# Altium schematic sheet Microphone and Speaker.SchDoc
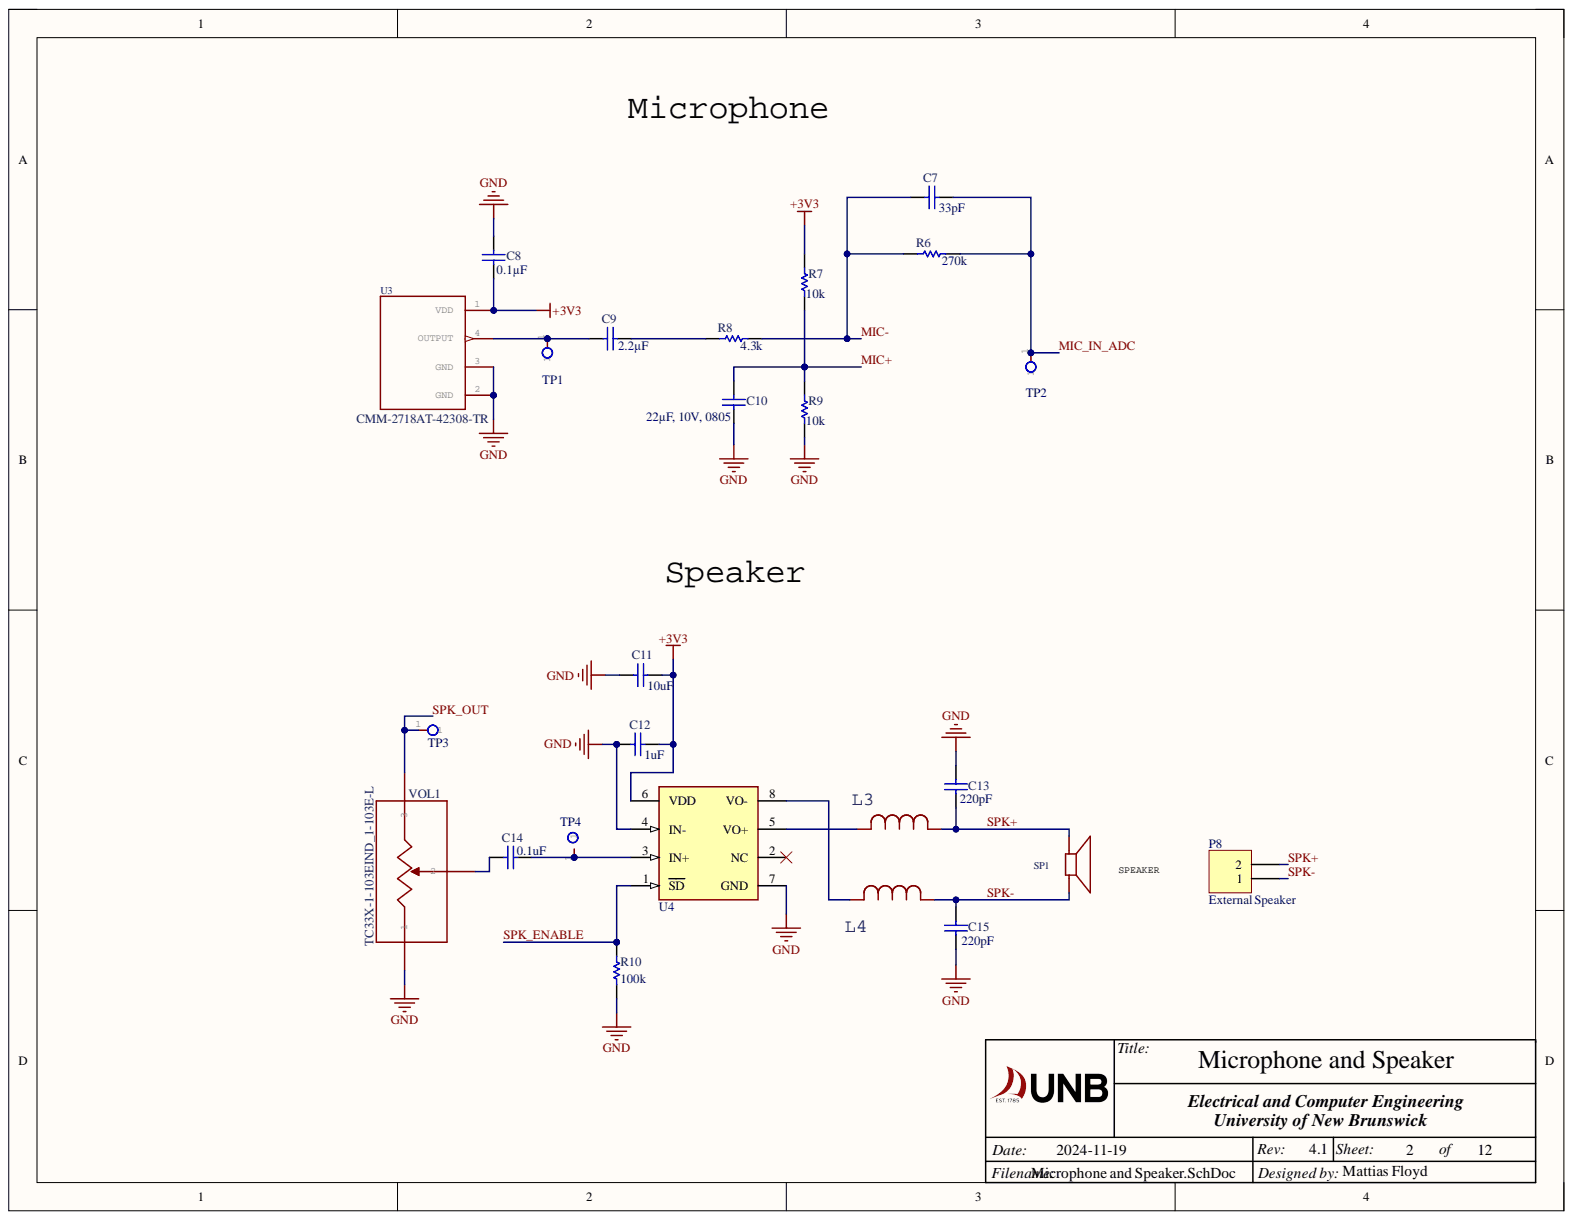
[diagram p0: Microphone and Speaker.SchDoc]

## Parts
C7  33pF  [0603 Cap]
C8  0.1µF  [0603 Cap]
C9  2.2µF  [0603 Cap]
C10  22µF, 10V, 0805  Case Code (Imperial): 0805  [0805 Cap]
C11  10uF  [0603 Cap]
C12  1uF  [0603 Cap]
C13  220pF  [0603 Cap]
C14  0.1uF  [0603 Cap]
C15  220pF  [0603 Cap]
L3  BLM18PG221SN1DIND_BLM18_0603_MUR-L  [IND_BLM18_0603_MUR-L]  (value not drawn)
L4  BLM18PG221SN1DIND_BLM18_0603_MUR-L  [IND_BLM18_0603_MUR-L]  (value not drawn)
P8  External Speaker  [HDR1X2]
R6  270k  [0603 Resistor]
R7  10k  [0603 Resistor]
R8  4.3k  [0603 Resistor]
R9  10k  [0603 Resistor]
R10  100k  [0603 Resistor]
SP1  SPEAKER  [AT-1127-ST-2-R]
TP1  Surface test point pad  [TEST_POINT]  (value not drawn)
TP2  Surface test point pad  [TEST_POINT]  (value not drawn)
TP3  Surface test point pad  [TEST_POINT]  (value not drawn)
TP4  Surface test point pad  [TEST_POINT]  (value not drawn)
U3  CMM-2718AT-42308-TR  [XDCR_CMM-2718AT-42308-TR]
U4  PAM8302AASCR  [FP-MSOP-8-IPC_A]  (value not drawn)
VOL1  TC33X-1-103EIND_1-103E-L  [TC33X-1]

## Nets
+3V3: C8.1 C11.2 C12.2 R7.2 U3.1(VDD) U4.6(VDD)
GND: C8.2 C10.1 C11.1 C12.1 C13.2 C15.1 R9.1 R10.2 U3.2(GND) U3.3(GND) U4.4(IN-) U4.7(GND) VOL1.1
MIC+: C10.2 R7.1 R9.2
MIC-: C7.1 R6.2 R8.1
MIC_IN_ADC: C7.2 R6.1 TP2.1
SPK+: C13.1 L3.1 P8.2 SP1.P$2
SPK-: C15.2 L4.1 P8.1 SP1.P$1
SPK_ENABLE: R10.1 U4.1(SD)
SPK_OUT: TP3.1 VOL1.3
NetC9_1: C9.1 TP1.1 U3.4(OUTPUT)
NetC9_2: C9.2 R8.2
NetC14_1: C14.1 VOL1.2
NetC14_2: C14.2 TP4.1 U4.3(IN+)
NetL3_2: L3.2 U4.5(VO+)
NetL4_2: L4.2 U4.8(VO-)

Not connected: U4 2(NC)

## Library data of the parts
C7 = Cap Semi: KEMET - C0603C330J1GACTU - SMD Multilayer Ceramic Capacitor, 33 pF, 100 V, 0603 [1608 Metric], &#177; 5%, C0G / NP0, C Series KEMET; Dissipation Factor: 0.1%; Temperature Coefficient: 30ppm/°C; Voltage Rating: 100V; Capacitance: 33pF; Case Code (Imperial): 0603; Case Code (Metric): 1608; Case/Package: 0603; Category: Ceramic Capacitors; Component Kind: Standard; ComponentLink1Description: http://www.avx.com/docs/Catalogs/cx7r.pdf; ComponentLink1URL: http://www.avx.com/docs/Catalogs/cx7r.pdf; ComponentLink2Description: https://datasheet.ciiva.com/13211/upy-gp-np0-16v-to-50v-8-13211019.pdf?src-supplier=Mouser; ComponentLink2URL: https://datasheet.ciiva.com/13211/upy-gp-np0-16v-to-50v-8-13211019.pdf?src-supplier=Mouser; ComponentLink3Description: https://datasheet.ciiva.com/7823/40889-7823734.pdf?src-supplier=Element14; ComponentLink3URL: https://datasheet.ciiva.com/7823/40889-7823734.pdf?src-supplier=Element14; ComponentLink4Description: https://datasheet.ciiva.com/5420/kem-c1003-c0g-smd-356956-5420521.pdf?src-supplier=Mouser; ComponentLink4URL: https://datasheet.ciiva.com/5420/kem-c1003-c0g-smd-356956-5420521.pdf?src-supplier=Mouser; ComponentLink5Description: https://datasheet.ciiva.com/22705/40889-22705434.pdf?src-supplier=Element14; ComponentLink5URL: https://datasheet.ciiva.com/22705/40889-22705434.pdf?src-supplier=Element14; ComponentLink6Description: https://datasheet.ciiva.com/28668/1789732-28668653.pdf?src-supplier=Element14; ComponentLink6URL: https://datasheet.ciiva.com/28668/1789732-28668653.pdf?src-supplier=Element14; Depth: 0.8mm; Dielectric: C0G; Dielectric Material: Ceramic; Height: 0.8mm; Lead Free: Lead Free; Lead Pitch: 0.7mm; Length: 1.6mm; Library Name: CAN_Interface_Library.SCHLIB; Manufacturer: KEMET; Manufacturer Part Number: C0603C330J1GACTU; Max Operating Temperature: 125°C; Min Operating Temperature: -55°C; Mount: Surface Mount; Number of Pins: 2; Package Quantity: 1; Packaging: Tape and Reel; REACH SVHC: No SVHC; RoHS: Yes; Signal Integrity: Cap Semi; Simulation: CAP; Stock 1: 150603; Supplier 1: Digi-Key; Supplier Part Number 1: 399-7896-1-ND; Termination: SMD/SMT; Thickness: 0.034inch; Tolerance: 5%; Value: 33pF; Voltage Rating (DC): 100V; Width: 0.031inch
C8 C14 = Cap Semi: Cap Ceramic 0.1uF 50VDC X7R 10% SMD 0603 Paper T/R; Manufacturer: Samsung; Manufacturer Part Number: CL10B104KB8NNNC; Capacitance: 100nF; Voltage: 50V; Case Code (Imperial): 0603; Case Code (Metric): 1608; Case/Package: 0603; Category: Ceramic Capacitors; Component Kind: Standard; ComponentLink1Description: http://www.avx.com/docs/Catalogs/cx7r.pdf; ComponentLink1URL: http://www.avx.com/docs/Catalogs/cx7r.pdf; ComponentLink2Description: https://datasheet.ciiva.com/25803/cl10b104kb8nnnc-25803393.pdf?src-supplier=Digi-Key; ComponentLink2URL: https://datasheet.ciiva.com/25803/cl10b104kb8nnnc-25803393.pdf?src-supplier=Digi-Key; ComponentLink3Description: https://datasheet.ciiva.com/26838/getdatasheetpartid-89097-26838411.pdf?src-supplier=Verical; ComponentLink3URL: https://datasheet.ciiva.com/26838/getdatasheetpartid-89097-26838411.pdf?src-supplier=Verical; ComponentLink4Description: https://datasheet.ciiva.com/29198/456651-da-01-en-vs-kondensator-0605-29198839.pdf?src-supplier=Conrad; ComponentLink4URL: https://datasheet.ciiva.com/29198/456651-da-01-en-vs-kondensator-0605-29198839.pdf?src-supplier=Conrad; Contact Plating: Tin; Depth: 0.8mm; Dielectric: X7R; Height: 0.8mm; Lead Free: Lead Free; Length: 1.6mm; Library Name: CAN_Interface_Library.SCHLIB; Material: Ceramic; Max Operating Temperature: 125°C; Min Operating Temperature: -55°C; Mount: Surface Mount; Package Quantity: 1; Packaging: Tape and Reel; Radiation Hardening: No; RoHS: Yes; Signal Integrity: Cap Semi; Simulation: CAP; Stock 1: 17879275; Supplier 1: Digi-Key; Supplier Part Number 1: 1276-1000-6-ND; Thickness: 0.035inch; Tolerance: 10%; Voltage Rating: 50V; Voltage Rating (DC): 50V; Width: 0.031inch
  C8: Value: 0.1µF
  C14: Value: 0.1uF
C9 = Cap Semi: SAMSUNG ELECTRO-MECHANICS - CL10A225KP8NNNC - SMD Multilayer Ceramic Capacitor, 2.2 µF, 10 V, 0603 [1608 Metric], ± 10%, X5R, CL Series; Capacitance: 2.2uF; Voltage: 10V; Case Code (Imperial): 0603; Case Code (Metric): 1608; Case/Package: 0603; Category: Ceramic Capacitors; Component Kind: Standard; ComponentLink1Description: http://www.avx.com/docs/Catalogs/cx7r.pdf; ComponentLink1URL: http://www.avx.com/docs/Catalogs/cx7r.pdf; ComponentLink2Description: https://datasheet.ciiva.com/25799/cl10a225kp8nnnc-25799697.pdf?src-supplier=Digi-Key; ComponentLink2URL: https://datasheet.ciiva.com/25799/cl10a225kp8nnnc-25799697.pdf?src-supplier=Digi-Key; ComponentLink3Description: https://datasheet.ciiva.com/26838/getdatasheetpartid-358617-26838359.pdf?src-supplier=Verical; ComponentLink3URL: https://datasheet.ciiva.com/26838/getdatasheetpartid-358617-26838359.pdf?src-supplier=Verical; ComponentLink4Description: https://datasheet.ciiva.com/29198/457945-da-01-en-vs-kondensator-0603-29198592.pdf?src-supplier=Conrad; ComponentLink4URL: https://datasheet.ciiva.com/29198/457945-da-01-en-vs-kondensator-0603-29198592.pdf?src-supplier=Conrad; Depth: 0.8mm; Dielectric: X5R; Height: 0.8mm; Lead Free: Lead Free; Length: 1.6mm; Library Name: CAN_Interface_Library.SCHLIB; Manufacturer: Samsung; Manufacturer Part Number: CL10A225KP8NNNC; Material: Ceramic; Max Operating Temperature: 85°C; Min Operating Temperature: -55°C; Mount: Surface Mount; Package Quantity: 1; Packaging: Tape and Reel; Radiation Hardening: No; RoHS: Yes; Signal Integrity: Cap Semi; Simulation: CAP; Stock 1: 1391778; Supplier 1: Digi-Key; Supplier Part Number 1: 1276-1085-1-ND; Thickness: 0.035inch; Tolerance: 10%; Value: 2.2µF; Voltage Rating: 10V; Voltage Rating (DC): 10V; Width: 0.031inch
C10 = Cap Semi: SAMSUNG ELECTRO-MECHANICS - CL21A106KOQNNNE - SMD Multilayer Ceramic Capacitor, 10 µF, 16 V, 0805 [2012 Metric], ± 10%, X5R, CL Series; Capacitance: 10uF; Dielectric: X7R; Voltage: 16V; Case Code (Metric): 2012; Case/Package: 0805; Category: Ceramic Capacitors; Component Kind: Standard; ComponentLink1Description: http://www.avx.com/docs/Catalogs/cx7r.pdf; ComponentLink1URL: http://www.avx.com/docs/Catalogs/cx7r.pdf; ComponentLink2Description: https://datasheet.ciiva.com/15216/getdatasheetpartid-358435-15216234.pdf?src-supplier=Verical; ComponentLink2URL: https://datasheet.ciiva.com/15216/getdatasheetpartid-358435-15216234.pdf?src-supplier=Verical; ComponentLink3Description: https://datasheet.ciiva.com/25798/cl21a106koqnnne-25798133.pdf?src-supplier=Digi-Key; ComponentLink3URL: https://datasheet.ciiva.com/25798/cl21a106koqnnne-25798133.pdf?src-supplier=Digi-Key; ComponentLink4Description: https://datasheet.ciiva.com/16740/cl05b104kq5nnnc-16740697.pdf?src-supplier=Future+Electronics; ComponentLink4URL: https://datasheet.ciiva.com/16740/cl05b104kq5nnnc-16740697.pdf?src-supplier=Future+Electronics; Depth: 1.25mm; Height: 1.25mm; Lead Free: Lead Free; Length: 2mm; Library Name: CAN_Interface_Library.SCHLIB; Manufacturer: Samsung; Manufacturer Part Number: CL21A106KOQNNNE; Max Operating Temperature: 85°C; Min Operating Temperature: -55°C; Mount: Surface Mount; Package Quantity: 1; Packaging: Tape and Reel; Radiation Hardening: No; RoHS: Yes; Signal Integrity: Cap Semi; Simulation: CAP; Stock 1: 963001; Supplier 1: Digi-Key; Supplier Part Number 1: 1276-1096-1-ND; Thickness: 0.055inch; Tolerance: 10%; Value: 22µF, 10V, 0805; Voltage Rating: 16V; Voltage Rating (DC): 16V; Width: 0.049inch
C11 = Cap Semi: Cap Ceramic 10uF 6.3V X5R 20% Pad SMD 0603 85°C T/R; Manufacturer: Samsung; Manufacturer Part Number: CL10A106MQ8NNNC; Capacitance: 10uF; Case Code (Imperial): 0603; Case Code (Metric): 1608; Case/Package: 0603; Category: Ceramic Capacitors; Component Kind: Standard; ComponentLink1Description: http://www.avx.com/docs/Catalogs/cx7r.pdf; ComponentLink1URL: http://www.avx.com/docs/Catalogs/cx7r.pdf; ComponentLink2Description: https://datasheet.ciiva.com/25798/cl10a106mq8nnnc-25798320.pdf?src-supplier=Digi-Key; ComponentLink2URL: https://datasheet.ciiva.com/25798/cl10a106mq8nnnc-25798320.pdf?src-supplier=Digi-Key; ComponentLink3Description: https://datasheet.ciiva.com/26838/getdatasheetpartid-357557-26838347.pdf?src-supplier=Verical; ComponentLink3URL: https://datasheet.ciiva.com/26838/getdatasheetpartid-357557-26838347.pdf?src-supplier=Verical; ComponentLink4Description: https://datasheet.ciiva.com/16437/cl05b104kq5nnnc-16437327.pdf?src-supplier=Future+Electronics; ComponentLink4URL: https://datasheet.ciiva.com/16437/cl05b104kq5nnnc-16437327.pdf?src-supplier=Future+Electronics; Contact Plating: Tin; Depth: 0.8mm; Dielectric: X5R; Height: 0.8mm; Length: 1.6mm; Library Name: CAN_Interface_Library.SCHLIB; Max Operating Temperature: 85°C; Min Operating Temperature: -55°C; Mount: Surface Mount; Package Quantity: 1; Packaging: Tape and Reel; Radiation Hardening: No; RoHS: Yes; Signal Integrity: Cap Semi; Simulation: CAP; Stock 1: 1321; Supplier 1: Digi-Key; Supplier Part Number 1: 1276-1119-1-ND; Thickness: 0.035inch; Tolerance: 20%; Value: 10uF; Voltage: 6.3V; Voltage Rating: 6.3V; Width: 0.031inch
C12 = Cap Semi: CAP CER 1UF 10V X7R 0603; Manufacturer: Samsung; Manufacturer Part Number: CL10B105KP8NFNC; Tolerance: 10%; Capacitance: 1uF; Case Code (Imperial): 0603; Case Code (Metric): 1608; Case/Package: 0603; Category: Ceramic Capacitors; Component Kind: Standard; ComponentLink1Description: http://www.avx.com/docs/Catalogs/cx7r.pdf; ComponentLink1URL: http://www.avx.com/docs/Catalogs/cx7r.pdf; ComponentLink2Description: https://datasheet.ciiva.com/25737/cl10b105kp8nfnc-25737841.pdf?src-supplier=Digi-Key; ComponentLink2URL: https://datasheet.ciiva.com/25737/cl10b105kp8nfnc-25737841.pdf?src-supplier=Digi-Key; Depth: 0.8mm; Dielectric: X7R; Height: 0.8mm; Length: 0.063inch; Library Name: CAN_Interface_Library.SCHLIB; Max Operating Temperature: 125°C; Min Operating Temperature: -55°C; Mount: Surface Mount; Package Quantity: 1; Packaging: Tape and Reel; Radiation Hardening: No; RoHS: Yes; Signal Integrity: Cap Semi; Simulation: CAP; Stock 1: 117122; Supplier 1: Digi-Key; Supplier Part Number 1: 1276-1945-1-ND; Thickness: 0.035inch; Value: 1uF; Voltage Rating: 10V; Width: 0.031inch
C13 C15 = Cap Semi: CL Series 0603 220 pF 50 V ±10% X7R Surface Mount Multilayer Ceramic Capacitor; Manufacturer: Samsung; Manufacturer Part Number: CL10B221KB8NFNC; Voltage Rating: 50V; Capacitance: 220pF; Case Code (Imperial): 0603; Case Code (Metric): 1608; Case/Package: 0603; Category: Ceramic Capacitors; Component Kind: Standard; ComponentLink1Description: http://www.avx.com/docs/Catalogs/cx7r.pdf; ComponentLink1URL: http://www.avx.com/docs/Catalogs/cx7r.pdf; ComponentLink2Description: https://datasheet.ciiva.com/25739/cl10b221kb8nfnc-25739329.pdf?src-supplier=Digi-Key; ComponentLink2URL: https://datasheet.ciiva.com/25739/cl10b221kb8nfnc-25739329.pdf?src-supplier=Digi-Key; ComponentLink3Description: https://datasheet.ciiva.com/16428/cl10b221kb8nfnc-16428861.pdf?src-supplier=Future+Electronics; ComponentLink3URL: https://datasheet.ciiva.com/16428/cl10b221kb8nfnc-16428861.pdf?src-supplier=Future+Electronics; Dielectric: X7R; Length: 0.063inch; Library Name: CAN_Interface_Library.SCHLIB; Max Operating Temperature: 125°C; Min Operating Temperature: -55°C; Mount: Surface Mount; Package Quantity: 1; Packaging: Tape and Reel; RoHS: Yes; Signal Integrity: Cap Semi; Simulation: CAP; Stock 1: 58768; Supplier 1: Digi-Key; Supplier Part Number 1: 1276-1986-1-ND; Thickness: 0.035inch; Tolerance: 10%; Value: 220pF; Width: 0.031inch
L3 L4 = BLM18PG221SN1DIND_BLM18_0603_MUR-L: Ferrite Beads Multi-Layer 220Ohm 25% 100MHz 1.4A 100mOhm DCR 0603 T/R; Manufacturer: Murata; DC Resistance (DCR): 100mR; ESR (Equivalent Series Resistance): 220R; Material: Steel,Ferrite; Number of Circuits: 1; Shielding: Unshielded; Case Code (Imperial): 0603; Case Code (Metric): 1608; Case/Package: 0603; Category: Ferrite Beads and Chips; Component Kind: Standard; ComponentLink1Description: https://datasheet.ciiva.com/19458/1845030-19458417.pdf?src-supplier=Jameco; ComponentLink1URL: https://datasheet.ciiva.com/19458/1845030-19458417.pdf?src-supplier=Jameco; ComponentLink2Description: https://datasheet.ciiva.com/11800/0900766b81275ca5-11800851.pdf?src-supplier=RSComponents; ComponentLink2URL: https://datasheet.ciiva.com/11800/0900766b81275ca5-11800851.pdf?src-supplier=RSComponents; ComponentLink3Description: https://datasheet.ciiva.com/29347/442391-da-01-en-chip-drossel-0603-220r-1400ma-1-6x0-8mm-29347986.pdf?src-supplier=Conrad; ComponentLink3URL: https://datasheet.ciiva.com/29347/442391-da-01-en-chip-drossel-0603-220r-1400ma-1-6x0-8mm-29347986.pdf?src-supplier=Conrad; ComponentLink4Description: https://datasheet.ciiva.com/1012/l0110s0100blm18p-1012161.pdf?src-supplier=Digi-Key; ComponentLink4URL: https://datasheet.ciiva.com/1012/l0110s0100blm18p-1012161.pdf?src-supplier=Digi-Key; ComponentLink5Description: https://datasheet.ciiva.com/3081/l0110s0100blm18p-3081633.pdf?src-supplier=Avnet; ComponentLink5URL: https://datasheet.ciiva.com/3081/l0110s0100blm18p-3081633.pdf?src-supplier=Avnet; ComponentLink6Description: https://datasheet.ciiva.com/1012/l0110s0100blm18p-1012162.pdf?src-supplier=Digi-Key; ComponentLink6URL: https://datasheet.ciiva.com/1012/l0110s0100blm18p-1012162.pdf?src-supplier=Digi-Key; ComponentLink7Description: https://datasheet.ciiva.com/1012/l0110s0100blm18p-1012163.pdf?src-supplier=Digi-Key; ComponentLink7URL: https://datasheet.ciiva.com/1012/l0110s0100blm18p-1012163.pdf?src-supplier=Digi-Key; ComponentLink8Description: https://datasheet.ciiva.com/8021/5148-8021479.pdf?src-supplier=Element14; ComponentLink8URL: https://datasheet.ciiva.com/8021/5148-8021479.pdf?src-supplier=Element14; ComponentLink9Description: https://datasheet.ciiva.com/14122/blm-series-eng-tds-14122909.pdf?src-supplier=Distrelec; ComponentLink9URL: https://datasheet.ciiva.com/14122/blm-series-eng-tds-14122909.pdf?src-supplier=Distrelec; ComponentLink10Description: https://datasheet.ciiva.com/16205/l0110s0100blm18p-16205422.pdf?src-supplier=Future+Electronics; ComponentLink10URL: https://datasheet.ciiva.com/16205/l0110s0100blm18p-16205422.pdf?src-supplier=Future+Electronics; ComponentLink11Description: https://datasheet.ciiva.com/8021/5148-8021482.pdf?src-supplier=Element14; ComponentLink11URL: https://datasheet.ciiva.com/8021/5148-8021482.pdf?src-supplier=Element14; Core Material: Ferrite; Current Rating: 1.4A; DC Current: 1.4A; Depth: 0.8mm; Height: 0.8mm; Impedance: 220R; Lead Free: Lead Free; Length: 1.6mm; Manufacturer Part Number: BLM18PG221SN1D; Max Current Rating: 1.4A; Max DC Current: 1.4A; Max Operating Temperature: 125°C; Min Operating Temperature: -55°C; Number of Lines: 1; Number of Pins: 2; Package Quantity: 1; Packaging: Tape and Reel; Radiation Hardening: No; REACH SVHC: No SVHC; Resistance: 100mR; RoHS: Yes; Stock 1: 141533; Supplier 1: Digi-Key; Supplier Part Number 1: 490-5221-1-ND; Termination: SMD/SMT; Test Frequency: 100MHz; Tolerance: 25%; Weight: 7.1E-05oz; Width: 0.8mm; Library Name: BrilliantLabsEagleLibrary.IntLib
P8 = Header 2: Header, 2-Pin; Component Kind: Standard; LatestRevisionDate: 17-Jul-2002; LatestRevisionNote: Re-released for DXP Platform.; Library Name: Miscellaneous Connectors.IntLib; Signal Integrity: Connector; Publisher: Altium Limited
R6 = Res Semi: Res Thick Film 0603 270K Ohm 1% 0.1W(1/10W) ±100ppm/C Pad SMD Automotive T/R; Manufacturer: Stackpole Electronics; Manufacturer Part Number: RMCF0603FT270K; Max Power Dissipation: 100mW; Resistance: 270kR; Temperature Coefficient: 100ppm/°C; Case Code (Imperial): 0603; Case Code (Metric): 1608; Case/Package: 0603; Category: Chip SMD Resistors; Component Kind: Standard; ComponentLink1Description: http://www.rohm.com/web/global/datasheet/ESR01MZPF/esr-e; ComponentLink1URL: http://www.rohm.com/web/global/datasheet/ESR01MZPF/esr-e; ComponentLink2Description: http://components.arrow.com/part/dataview/50861785S7394934N1174?src-supplier=Arrow+Electronics; ComponentLink2URL: http://components.arrow.com/part/dataview/50861785S7394934N1174?src-supplier=Arrow+Electronics; ComponentLink3Description: https://www.seielect.com/catalog/SEI-RMCF_RMCP.pdf?src-supplier=Avnet; ComponentLink3URL: https://www.seielect.com/catalog/SEI-RMCF_RMCP.pdf?src-supplier=Avnet; ComponentLink4Description: https://www.seielect.com/Catalog/SEI-RMCF_RMCP.pdf?src-supplier=Digi-Key; ComponentLink4URL: https://www.seielect.com/Catalog/SEI-RMCF_RMCP.pdf?src-supplier=Digi-Key; ComponentLink5Description: https://www.seielect.com/catalog/SEI-Packaging.pdf?src-supplier=Digi-Key; ComponentLink5URL: https://www.seielect.com/catalog/SEI-Packaging.pdf?src-supplier=Digi-Key; Composition: Thick Film; Contact Plating: Tin,Matte; Depth: 0.8mm; Features: Automotive AEC-Q200; Height: 0.022inch; Lead Free: Lead Free; Length: 0.061inch; Max Operating Temperature: 155°C; Military Standard: Not; Min Operating Temperature: -55°C; Mount: Surface Mount; Number of Terminations: 2; Packaging: Tape and Reel; Radiation Hardening: No; RoHS: Yes; Signal Integrity: Res Semi; Simulation: RES; Stock 1: 61185; Supplier 1: Digi-Key; Supplier Part Number 1: RMCF0603FT270KCT-ND; Tolerance: 1%; Value: 270k; Width: 0.031inch; Library Name: BrillianBit.SCHLIB
R7 R9 = Res Semi: Res Thick Film 0603 10K Ohm 1% 0.1W(1/10W) ±100ppm/C Molded SMD Automotive T/R; Manufacturer: Stackpole Electronics; Manufacturer Part Number: RMCF0603FT10K0; Max Power Dissipation: 100mW; Resistance: 10kR; Temperature Coefficient: 100ppm/°C; Case Code (Imperial): 0603; Case Code (Metric): 1608; Case/Package: 0603; Category: Chip SMD Resistors; Component Kind: Standard; ComponentLink1Description: http://www.rohm.com/web/global/datasheet/ESR01MZPF/esr-e; ComponentLink1URL: http://www.rohm.com/web/global/datasheet/ESR01MZPF/esr-e; ComponentLink2Description: http://components.arrow.com/part/dataview/43747082S6756917N1174?src-supplier=Arrow+Electronics; ComponentLink2URL: http://components.arrow.com/part/dataview/43747082S6756917N1174?src-supplier=Arrow+Electronics; ComponentLink3Description: https://www.seielect.com/catalog/sei-rmcf_rmcp.pdf?src-supplier=Digi-Key; ComponentLink3URL: https://www.seielect.com/catalog/sei-rmcf_rmcp.pdf?src-supplier=Digi-Key; ComponentLink4Description: https://www.seielect.com/catalog/SEI-RMCF_RMCP.pdf?src-supplier=Avnet; ComponentLink4URL: https://www.seielect.com/catalog/SEI-RMCF_RMCP.pdf?src-supplier=Avnet; ComponentLink5Description: http://www.seielect.com/catalog/sei-rmc.pdf?src-supplier=Future+Electronics; ComponentLink5URL: http://www.seielect.com/catalog/sei-rmc.pdf?src-supplier=Future+Electronics; ComponentLink6Description: https://www.verical.com/server-webapp/html/getDatasheet?partID=360913&src-supplier=Verical; ComponentLink6URL: https://www.verical.com/server-webapp/html/getDatasheet?partID=360913&src-supplier=Verical; Composition: Thick Film; Contact Plating: Tin,Matte; Depth: 0.8mm; Features: Automotive AEC-Q200; Height: 0.022inch; Lead Free: Lead Free; Length: 1.55mm; Max Operating Temperature: 155°C; Military Standard: Not; Min Operating Temperature: -55°C; Mount: Surface Mount; Number of Terminations: 2; Packaging: Tape and Reel; Power Rating: 100mW; Radiation Hardening: No; RoHS: Yes; Signal Integrity: Res Semi; Simulation: RES; Stock 1: 1608506; Supplier 1: Digi-Key; Supplier Part Number 1: RMCF0603FT10K0CT-ND; Tolerance: 1%; Value: 10k; Width: 0.031inch; Library Name: BrillianBit.SCHLIB
R8 = Res Semi: RES SMD 4.3K OHM 1% 1/10W 0603; Manufacturer: Stackpole Electronics; Manufacturer Part Number: RMCF0603FT4K30; Max Power Dissipation: 100mW; Resistance: 4.3kR; Temperature Coefficient: 100ppm/°C; Case Code (Imperial): 0603; Case Code (Metric): 1608; Case/Package: 0603; Category: Chip SMD Resistors; Component Kind: Standard; ComponentLink1Description: http://www.rohm.com/web/global/datasheet/ESR01MZPF/esr-e; ComponentLink1URL: http://www.rohm.com/web/global/datasheet/ESR01MZPF/esr-e; ComponentLink2Description: http://components.arrow.com/part/dataview/50496135S184625N1174?src-supplier=Arrow+Electronics; ComponentLink2URL: http://components.arrow.com/part/dataview/50496135S184625N1174?src-supplier=Arrow+Electronics; ComponentLink3Description: https://www.seielect.com/catalog/SEI-RMCF_RMCP.pdf?src-supplier=Avnet; ComponentLink3URL: https://www.seielect.com/catalog/SEI-RMCF_RMCP.pdf?src-supplier=Avnet; ComponentLink4Description: https://www.seielect.com/Catalog/SEI-RMCF_RMCP.pdf?src-supplier=Digi-Key; ComponentLink4URL: https://www.seielect.com/Catalog/SEI-RMCF_RMCP.pdf?src-supplier=Digi-Key; ComponentLink5Description: https://www.seielect.com/catalog/SEI-Packaging.pdf?src-supplier=Digi-Key; ComponentLink5URL: https://www.seielect.com/catalog/SEI-Packaging.pdf?src-supplier=Digi-Key; Composition: Thick Film; Contact Plating: Tin,Matte; Features: Automotive AEC-Q200; Height: 0.022inch; Lead Free: Lead Free; Length: 0.061inch; Max Operating Temperature: 155°C; Min Operating Temperature: -55°C; Mount: Surface Mount; Number of Terminations: 2; Packaging: Tape and Reel; Radiation Hardening: No; RoHS: Yes; Signal Integrity: Res Semi; Simulation: RES; Stock 1: 36145; Supplier 1: Digi-Key; Supplier Part Number 1: RMCF0603FT4K30CT-ND; Tolerance: 1%; Value: 4.3k; Width: 0.031inch; Library Name: BrillianBit.SCHLIB
R10 = Res Semi: RES 100K OHM 1% 1/10W 0603; Manufacturer: Stackpole Electronics; Manufacturer Part Number: RMCF0603FT100K; Max Power Dissipation: 100mW; Resistance: 100kR; Temperature Coefficient: 100ppm/°C; Working Voltage: 75V; Case Code (Imperial): 0603; Case Code (Metric): 1608; Case/Package: 0603; Category: Chip SMD Resistors; Component Kind: Standard; ComponentLink1Description: http://www.rohm.com/web/global/datasheet/ESR01MZPF/esr-e; ComponentLink1URL: http://www.rohm.com/web/global/datasheet/ESR01MZPF/esr-e; ComponentLink2Description: https://datasheet.ciiva.com/15193/getdatasheetpartid-362597-15193437.pdf?src-supplier=Verical; ComponentLink2URL: https://datasheet.ciiva.com/15193/getdatasheetpartid-362597-15193437.pdf?src-supplier=Verical; Composition: Thick Film; Contact Plating: Tin,Matte; Depth: 0.8mm; Features: Automotive AEC-Q200; Height: 0.022inch; Lead Free: Lead Free; Length: 1.55mm; Max Operating Temperature: 155°C; Military Standard: Not; Min Operating Temperature: -55°C; Mount: Surface Mount; Number of Terminations: 2; Packaging: Tape and Reel; Power Rating: 100mW; Radiation Hardening: No; RoHS: Yes; Signal Integrity: Res Semi; Simulation: RES; Stock 1: 2666095; Supplier 1: Digi-Key; Supplier Part Number 1: RMCF0603FT100KDKR-ND; Tolerance: 1%; Value: 100k; Width: 0.031inch; Library Name: BrillianBit.SCHLIB
SP1 = SPEAKER: AUDIO MAGNETIC XDCR 2-4V TH; Manufacturer: Projects Unlimited; Frequency: 2.73kHz; Operating Supply Current: 80mA; Voltage Rating: 3V; Category: Audio Products; Component Kind: Standard; ComponentLink1Description: http://www.mouser.com/ds/2/334/AT-1127-ST-2-R-532246.pdf?src-supplier=Mouser; ComponentLink1URL: http://www.mouser.com/ds/2/334/AT-1127-ST-2-R-532246.pdf?src-supplier=Mouser; ComponentLink2Description: http://www.puiaudio.com/pdf/AT-1127-ST-2-R.pdf?src-supplier=Digi-Key; ComponentLink2URL: http://www.puiaudio.com/pdf/AT-1127-ST-2-R.pdf?src-supplier=Digi-Key; ComponentLink3Description: http://www.puiaudio.com/pdf/AT-1127-ST-2-R.pdf?src-supplier=Avnet; ComponentLink3URL: http://www.puiaudio.com/pdf/AT-1127-ST-2-R.pdf?src-supplier=Avnet; Contact Plating: Gold; Diameter: 9mm; Height: 4.5mm; Manufacturer Part Number: AT-1127-ST-2-R; Max Operating Temperature: 70°C; Min Operating Temperature: -20°C; Mount: Through Hole; Packaging: Tray; RoHS: Yes; Sensing Method: Magnetic; Stock 1: 14405; Supplier 1: Digi-Key; Supplier Part Number 1: 668-1463-ND; Termination: PC Pins; Library Name: BrilliantLabsEagleLibrary.IntLib
TP1-TP4 = TESTPOINT: GateName_1: A; SymbolName_1: TP; Component Kind: Standard; DeviceSetName: TESTPOINT; Package: TEST_POINT; Library Name: bBoard for UNB Schematic Library.SCHLIB; LibraryName: BrilliantLabsEagleLibrary
U3 = CMM-2718AT-42308-TR: 2.75 x 1.85 mm, Analog, Top Port, Surface Mount, 2.0 Vdc, -42 ±3 dB, MEMS Microphone; Manufacturer: CUI Devices; Component Kind: Standard; ComponentLink1Description: http://datasheet.octopart.com/CMM-2718AT-42308-TR-CUI-Devices-datasheet-142081213.pdf?src-supplier=Electro+Sonic; ComponentLink1URL: http://datasheet.octopart.com/CMM-2718AT-42308-TR-CUI-Devices-datasheet-142081213.pdf?src-supplier=Electro+Sonic; ComponentLink2Description: http://datasheet.octopart.com/CMM-2718AT-42308-TR-CUI-Devices-datasheet-137938000.pdf?src-supplier=CUI+Devices; ComponentLink2URL: http://datasheet.octopart.com/CMM-2718AT-42308-TR-CUI-Devices-datasheet-137938000.pdf?src-supplier=CUI+Devices; ComponentLink3Description: http://datasheet.octopart.com/CMM-2718AT-42308-TR-CUI-Devices-datasheet-142347714.pdf?src-supplier=CUI+Devices+SCT; ComponentLink3URL: http://datasheet.octopart.com/CMM-2718AT-42308-TR-CUI-Devices-datasheet-142347714.pdf?src-supplier=CUI+Devices+SCT; ComponentLink4Description: http://datasheet.octopart.com/CMM-2718AT-42308-TR-CUI-datasheet-120182442.pdf?src-supplier=CUI; ComponentLink4URL: http://datasheet.octopart.com/CMM-2718AT-42308-TR-CUI-datasheet-120182442.pdf?src-supplier=CUI; Manufacturer Part Number: CMM-2718AT-42308-TR; Stock 1: 465; Supplier 1: Digi-Key; Supplier Part Number 1: 102-5092-1-ND; Library Name: bBoard for UNB Schematic Library.SCHLIB
U4 = PAM8302AASCR: Audio Amplifier, 1 Func, PDSO8; Manufacturer: Diodes; Number of Functions: 1; Package Body Material: Plastic; Package Equivalence Code: TSSOP8,.19; Power Supplies: 2.5/5V; Qualification Status: Not Qualified; Category: Amplifiers - Audio; Component Kind: Standard; ComponentLink1Description: https://datasheet.ciiva.com:443/pdfs/VipMasterIC/IC/DIOD/DIOD-S-A0006455643/DIOD-S-A0006455643-1.pdf?src-supplier=IHS+Markit; ComponentLink1URL: https://datasheet.ciiva.com:443/pdfs/VipMasterIC/IC/DIOD/DIOD-S-A0006455643/DIOD-S-A0006455643-1.pdf?src-supplier=IHS+Markit; ComponentLink2Description: https://datasheet.ciiva.com/14921/pam8302a-14921405.pdf?src-supplier=Digikey; ComponentLink2URL: https://datasheet.ciiva.com/14921/pam8302a-14921405.pdf?src-supplier=Digikey; ComponentLink3Description: https://datasheet.ciiva.com/29896/pam8302a-29896329.pdf?src-supplier=Avnet; ComponentLink3URL: https://datasheet.ciiva.com/29896/pam8302a-29896329.pdf?src-supplier=Avnet; ComponentLink4Description: https://datasheet.ciiva.com/24013/pam8302a-24013158.pdf?src-supplier=Mouser; ComponentLink4URL: https://datasheet.ciiva.com/24013/pam8302a-24013158.pdf?src-supplier=Mouser; Consumer IC Type: AUDIO AMPLIFIER; JESD-30 Code: R-PDSO-G8; JESD-609 Code: e3; Manufacturer Part Number: PAM8302AASCR; Max Operating Temperature: 85°C; Min Operating Temperature: -40°C; Moisture Sensitivity Level: 3; Number of Pins: 8; Package Code: TSSOP; Package Shape: Rectangular; Package Style: SMALL OUTLINE, THIN PROFILE, SHRINK PITCHMeter; Pricing 1: 1=0.41, 10=0.361, 25=0.3388, 100=0.2766, 250=0.25696, 500=0.21868, 1000=0.1925 (USD); Reach SVHC Compliant: Yes; RoHS: Yes; RoHS Compliant: Yes; Stock 1: 102077; Supplier 1: Digikey; Supplier Part Number 1: PAM8302AASCRDICT-ND; Surface Mount: Yes; Temperature Grade: INDUSTRIAL; Terminal Finish: Tin; Terminal Form: Gull Wing; Terminal Pitch: 0.635mm; Terminal Position: DUAL; Library Name: bBoard for UNB Rev 4.SCHLIB
VOL1 = TC33X-1-103EIND_1-103E-L: Res Trimmer 10K Ohm 25% 1/10W 1(Elec)/1(Mech)Turn (3 X 3.8mm) Foot Print SMD Embossed T/R; Manufacturer: Bourns; Max Power Dissipation: 100mW; Resistance: 10kR; Temperature Coefficient: 250ppm/°C; Category: Variable Resistors and Potentiometers; Component Kind: Standard; ComponentLink1Description: https://datasheet.ciiva.com/17562/tc33-17562453.pdf?src-supplier=Avnet+Europe; ComponentLink1URL: https://datasheet.ciiva.com/17562/tc33-17562453.pdf?src-supplier=Avnet+Europe; ComponentLink2Description: https://datasheet.ciiva.com/2159/tc33-2159804.pdf?src-supplier=Digi-Key; ComponentLink2URL: https://datasheet.ciiva.com/2159/tc33-2159804.pdf?src-supplier=Digi-Key; Composition: Cermet; Depth: 3.8mm; Height: 0.047inch; Length: 3mm; Manufacturer Part Number: TC33X-1-103E; Max Operating Temperature: 100°C; Military Standard: Not; Min Operating Temperature: -40°C; Mount: Surface Mount; Package Quantity: 2500; Packaging: Tape and Reel; Power Rating: 100mW; RoHS: Yes; Stock 1: 25513; Supplier 1: Digi-Key; Supplier Part Number 1: TC33X-1-103ECT-ND; Termination: Foot Print; Tolerance: 25%; Width: 0.118inch; Library Name: BrilliantLabsEagleLibrary.IntLib
